# Altium schematic sheet DigitalOutput.SchDoc
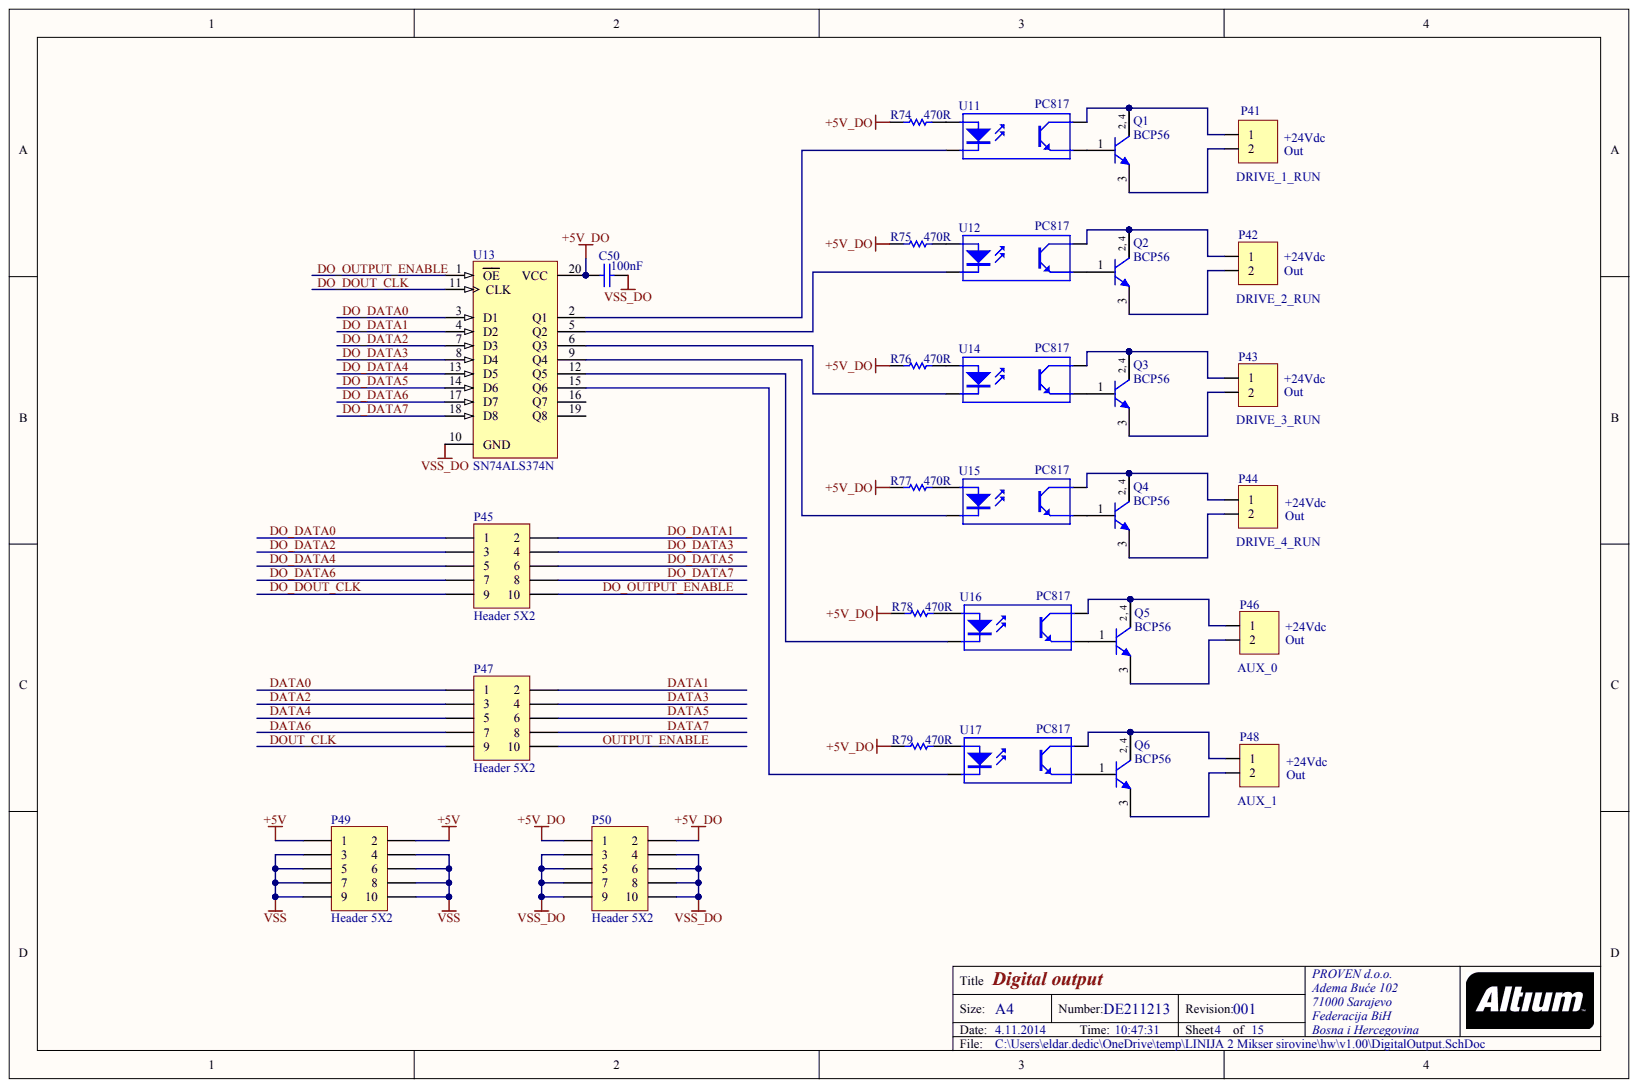
[diagram p0: DigitalOutput.SchDoc]

## Parts
C50  value 100nF
P41  DRIVE_1_RUN
P42  DRIVE_2_RUN
P43  DRIVE_3_RUN
P44  DRIVE_4_RUN
P45  Header 5X2
P46  AUX_0
P47  Header 5X2
P48  AUX_1
P49  Header 5X2
P50  Header 5X2
Q1  BCP56
Q2  BCP56
Q3  BCP56
Q4  BCP56
Q5  BCP56
Q6  BCP56
R74  470R
R75  470R
R76  470R
R77  470R
R78  470R
R79  470R
U11  PC817
U12  PC817
U13  SN74ALS374N
U14  PC817
U15  PC817
U16  PC817
U17  PC817

## Nets
+5V: P49.1 P49.2
+5V_DO: C50.1 P50.1 P50.2 R74.2 R75.2 R76.2 R77.2 R78.2 R79.2 U13.20(VCC)
VSS: P49.3 P49.4 P49.5 P49.6 P49.7 P49.8 P49.9 P49.10
VSS_DO: C50.2 P50.3 P50.4 P50.5 P50.6 P50.7 P50.8 P50.9 P50.10 U13.10(GND)
DATA0: P47.1
DATA1: P47.2
DATA2: P47.3
DATA3: P47.4
DATA4: P47.5
DATA5: P47.6
DATA6: P47.7
DATA7: P47.8
DO_DATA0: P45.1 U13.3(D1)
DO_DATA1: P45.2 U13.4(D2)
DO_DATA2: P45.3 U13.7(D3)
DO_DATA3: P45.4 U13.8(D4)
DO_DATA4: P45.5 U13.13(D5)
DO_DATA5: P45.6 U13.14(D6)
DO_DATA6: P45.7 U13.17(D7)
DO_DATA7: P45.8 U13.18(D8)
DO_DOUT_CLK: P45.9 U13.11(CLK)
DO_OUTPUT_ENABLE: P45.10 U13.1(OE)
DOUT_CLK: P47.9
OUTPUT_ENABLE: P47.10
NetP41_1: P41.1 Q1.2 Q1.4 U11.4
NetP41_2: P41.2 Q1.3
NetP42_1: P42.1 Q2.2 Q2.4 U12.4
NetP42_2: P42.2 Q2.3
NetP43_1: P43.1 Q3.2 Q3.4 U14.4
NetP43_2: P43.2 Q3.3
NetP44_1: P44.1 Q4.2 Q4.4 U15.4
NetP44_2: P44.2 Q4.3
NetP46_1: P46.1 Q5.2 Q5.4 U16.4
NetP46_2: P46.2 Q5.3
NetP48_1: P48.1 Q6.2 Q6.4 U17.4
NetP48_2: P48.2 Q6.3
NetQ1_1: Q1.1 U11.3
NetQ2_1: Q2.1 U12.3
NetQ3_1: Q3.1 U14.3
NetQ4_1: Q4.1 U15.3
NetQ5_1: Q5.1 U16.3
NetQ6_1: Q6.1 U17.3
NetR74_1: R74.1 U11.1
NetR75_1: R75.1 U12.1
NetR76_1: R76.1 U14.1
NetR77_1: R77.1 U15.1
NetR78_1: R78.1 U16.1
NetR79_1: R79.1 U17.1
NetU11_2: U11.2 U13.2(Q1)
NetU12_2: U12.2 U13.5(Q2)
NetU13_6: U13.6(Q3) U14.2
NetU13_9: U13.9(Q4) U15.2
NetU13_12: U13.12(Q5) U16.2
NetU13_15: U13.15(Q6) U17.2

Not connected: U13 16(Q7) 19(Q8)

## Library data of the parts
R74-R79 = (no symbol name): Note: If flow soldering, adjust pad width of PCB Footprint to 0.7 - 0.8 times the width of the resistor.; Published: 17-Dec-2003; LatestRevisionDate: 19-Mar-2004; LatestRevisionNote: Silkscreen size reduced.; PackageDocument: Mar-2003; PackageReference: ERA-0805; Code_IPC: 2012 [0805]; ComponentLink1Description: Manufacturer Link; ComponentLink1URL: http://www.panasonic.com/industrial/components/resistive_products.htm; ComponentLink2Description: Datasheet; ComponentLink2URL: http://www.panasonic.com/industrial/components/pdf/AOF0000CE1.pdf; Publisher: Altium Limited
C50 P41-P50 Q1-Q6 U11-U17 = (no symbol name)
  C50: Published: 17-Jul-2002; LatestRevisionDate: 19-Mar-2004; LatestRevisionNote: Silkscreen size reduced.; DatasheetDocument: Mar-2001; PackageDocument: Mar-2001; PackageReference: 1206; Code_JEITA: 1206; Code_IPC: 3216 [1206]; ComponentLink1Description: Manufacturer Link; ComponentLink1URL: http://www.kemet.com/; ComponentLink2Description: Datasheet; ComponentLink2URL: http://www.kemet.com/kemet/web/homepage/kechome.nsf/vapubfilesname/F3102TA.pdf/$file/F3102TA.pdf
  P45 P47 P49 P50: LatestRevisionDate: 17-Jul-2002; LatestRevisionNote: Re-released for DXP Platform.
  Q1-Q6: Published: 17-Sep-2003; LatestRevisionDate: 12-Dec-2006; LatestRevisionNote: IPC-7351 Footprint Added.; DatasheetVersion: 10-Oct-2001; PackageVersion: Aug-2001; PackageReference: SOT223; PackageDescription: 4-Pin SOT-223 Package 2.3 mm Pitch, 7 mm Lead Span; ComponentLink1Description: Manufacturer Link; ComponentLink1URL: http://www.semiconductors.philips.com/; ComponentLink2Description: Datasheet; ComponentLink2URL: http://www.semiconductors.philips.com/acrobat/datasheets/BC639_BCP56_BCX56_7.pdf
  U11 U12 U14-U17: Published: 24-Mar-1999; LatestRevisionDate: 04-Mar-2005; LatestRevisionNote: Sch pins 3 & 4 Swapped.; DatasheetVersion: Nov-2003; PackageReference: DIP-4
  U13: Published: 8-Jun-2000; LatestRevisionDate: 04-Mar-2005; LatestRevisionNote: Stylized 3D Model Added.; DatasheetVersion: May-1986; PackageVersion: Oct-1995; PackageReference: N020D; PackageDescription: DIP; 20 Leads; Row Spacing 7.62 mm; Pitch 2.54 mm; ComponentLink1Description: Manufacturer Link; ComponentLink1URL: http://www.ti.com/
  C50 P45 P47 P49 P50 Q1-Q6 U11-U17: Publisher: Altium Limited
